# Altium schematic sheet SuperClock_Core_PWR.SchDoc(SuperClock_Core_PWR)
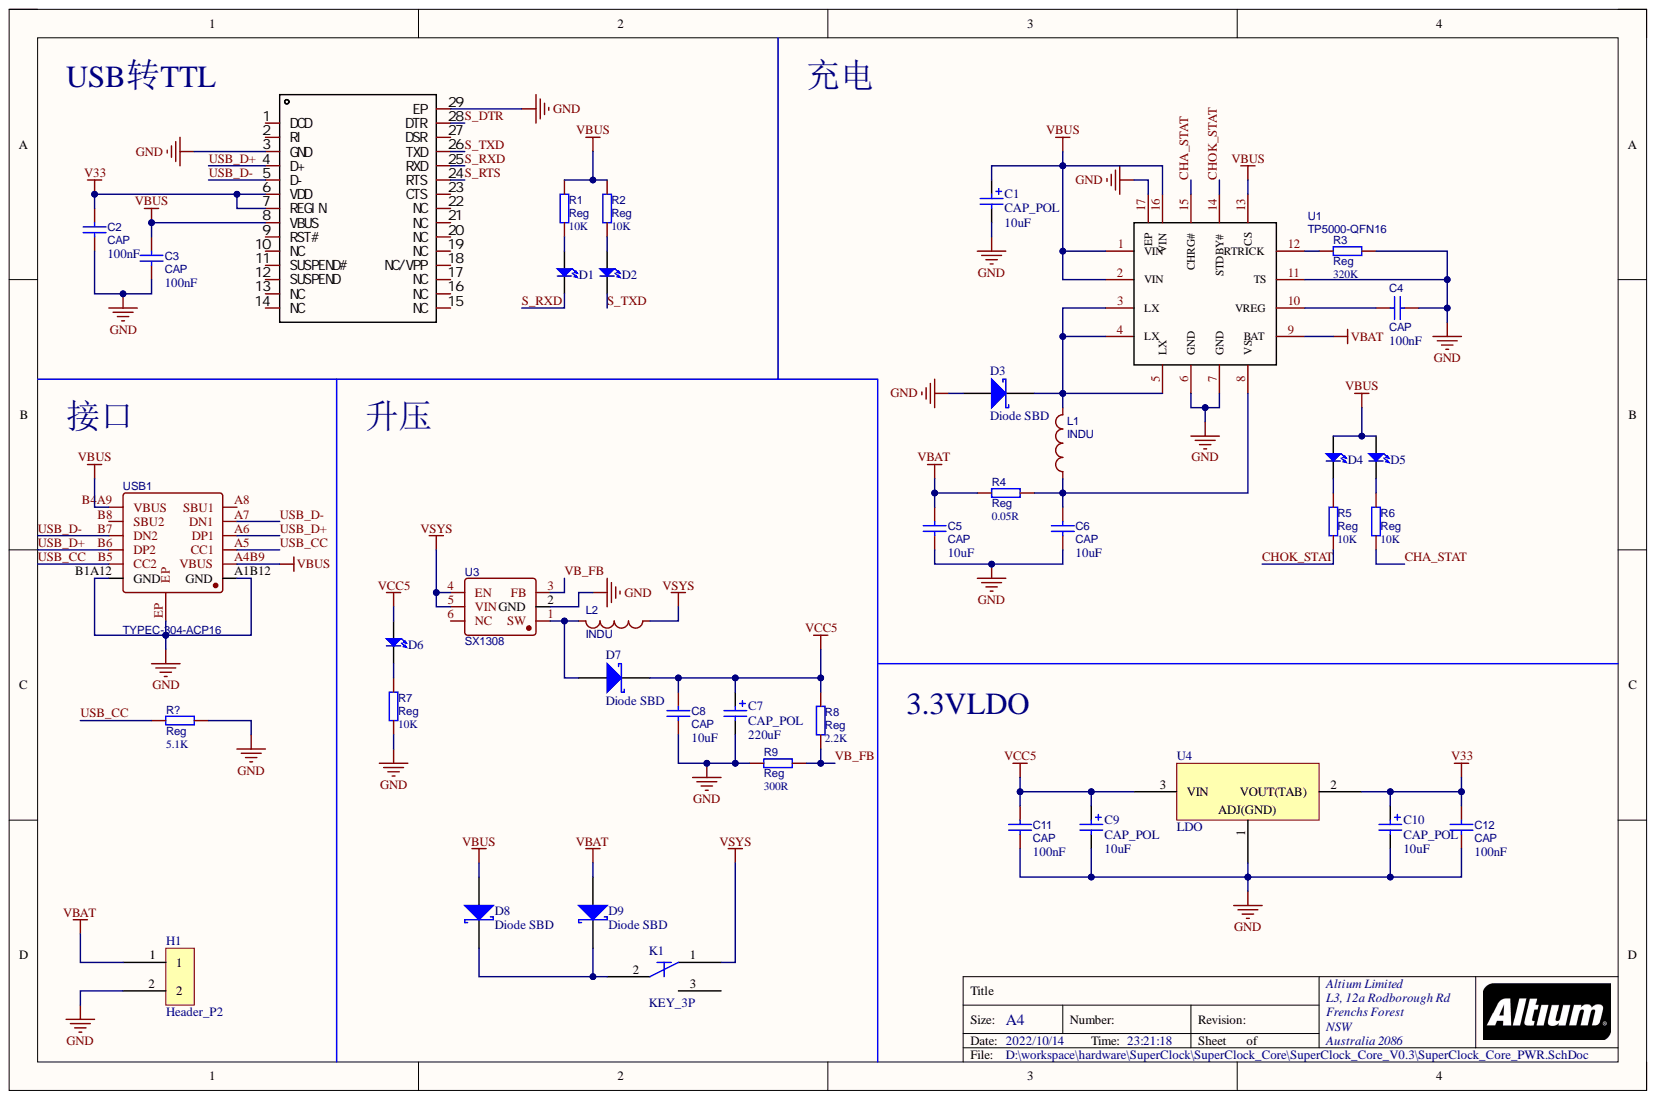
[diagram p0: SuperClock_Core_PWR.SchDoc]

## Parts
C1  CAP_POL  value 10uF  [CP1210]
C2  CAP  value 100nF  [C0603]
C3  CAP  value 100nF  [C0603]
C4  CAP  value 100nF  [C0603]
C5  CAP  value 10uF  [C0603]
C6  CAP  value 10uF  [C0603]
C7  CAP_POL  value 220uF  [CAP_SMD_6.3mm]
C8  CAP  value 10uF  [C0603]
C9  CAP_POL  value 10uF  [CP1210]
C10  CAP_POL  value 10uF  [CP1210]
C11  CAP  value 100nF  [C0603]
C12  CAP  value 100nF  [C0603]
D1  LED  [LED0603]  (value not drawn)
D2  LED  [LED0603]  (value not drawn)
D3  Diode SBD  [SMA_L4.3]
D4  LED  [LED0603]  (value not drawn)
D5  LED  [LED0603]  (value not drawn)
D6  LED  [LED0603]  (value not drawn)
D7  Diode SBD  [SMA_L4.3]
D8  Diode SBD  [SMA_L4.3]
D9  Diode SBD  [SMA_L4.3]
H1  Header_P2  [PH_2Pin]
K1  KEY_3P  [SWITCH_3P_2]
L1  INDU  [SMD_L7.1]
L2  INDU  [SMD_L7.1]
R1  Reg  value 10K  [R0603]
R2  Reg  value 10K  [R0603]
R3  Reg  value 320K  [R0603]
R4  Reg  value 0.05R  [R1206]
R5  Reg  value 10K  [R0603]
R6  Reg  value 10K  [R0603]
R7  Reg  value 10K  [R0603]
R8  Reg  value 2.2K  [R0603]
R9  Reg  value 300R  [R0603]
R?  Reg  value 5.1K  [R0603]
U1  TP5000-QFN16  [QFN-16_L4.0-W4.0-P0.65-BL-EP2.2]
U2  CP2102-GM  [QFN-28]  (value not drawn)
U3  SX1308  [SOT-23-6]
U4  LDO  [SOT-223]
USB1  TYPEC-304-ACP16  [USB-C-SMD_TYPE-C-16P]

## Nets
GND: C1.2 C2.2 C3.2 C4.2 C5.2 C6.2 C7.2 C8.2 C9.2 C10.2 C11.2 C12.2 D3.2 H1.2 R3.2 R7.1 R9.2 R?.1 U1.6(GND) U1.7(GND) U1.11(TS) U1.17(EP) U2.3(GND) U2.29(EP) U3.2(GND) U4.1 USB1.A1B12(GND) USB1.B1A12(GND) USB1.EP
V33: C2.1 C10.1 C12.1 U2.6(VDD) U2.7(REGIN) U4.2
VBAT: C5.1 D9.2 H1.1 R4.1 U1.9(BAT)
VBUS: C1.1 C3.1 D4.2 D5.2 D8.2 R1.2 R2.2 U1.1(VIN) U1.2(VIN) U1.13(CS) U1.16(VIN) U2.8(VBUS) USB1.A4B9(VBUS) USB1.B4A9(VBUS)
VCC5: C7.1 C8.1 C9.1 C11.1 D6.2 D7.1 R8.2 U4.3
VSYS: K1.1 L2.1 U3.4(EN) U3.5(VIN)
CHA_STAT: R6.1 U1.15(CHRG#)
CHOK_STAT: R5.1 U1.14(STDBY#)
S_DTR: U2.28(DTR)
S_RTS: U2.24(RTS)
S_RXD: D1.1 U2.25(RXD)
S_TXD: D2.1 U2.26(TXD)
USB_CC: R?.2 USB1.A5(CC1) USB1.B5(CC2)
USB_D+: U2.4(D+) USB1.A6(DP1) USB1.B6(DP2)
USB_D-: U2.5(D-) USB1.A7(DN1) USB1.B7(DN2)
VB_FB: R8.1 R9.1 U3.3(FB)
NetC4_1: C4.1 U1.10(VREG)
NetC6_1: C6.1 L1.1 R4.2 U1.8(VS)
NetD1_2: D1.2 R1.1
NetD2_2: D2.2 R2.1
NetD3_1: D3.1 L1.2 U1.3(LX) U1.4(LX) U1.5(LX)
NetD4_1: D4.1 R5.2
NetD5_1: D5.1 R6.2
NetD6_1: D6.1 R7.2
NetD7_2: D7.2 L2.2 U3.1(SW)
NetD8_1: D8.1 D9.1 K1.2
NetR3_1: R3.1 U1.12(RTRICK)

Not connected: K1 3; U2 1(DCD) 2(RI) 9(RST#) 10(NC) 11(SUSPEND#) 12(SUSPEND) 13(NC) 14(NC) 15(NC) 16(NC) 17(NC) 18(NC/VPP) 19(NC) 20(NC) 21(NC) 22(NC) 23(CTS) 27(DSR); U3 6(NC); USB1 A8(SBU1) B8(SBU2)

## Library data of the parts
C1 C7 C9 C10 = CAP_POL: 极性电容; Component Kind: Standard; Library Name: Levent_lib.IntLib
C2-C6 C8 C11 C12 = CAP: BOM_Manufacturer: Huaxin S&T; BOM_Manufacturer Part: 0603B103K101CT; Contributor: LCSC; nameAlias: Capacitance; BOM_Supplier: LCSC; BOM_Supplier Part: C302008; Component Kind: Standard; Description: Cap; spicePre: C; spiceSymbolName: 0603B103K101CT; Library Name: Levent_lib.IntLib
D1 D2 D4-D6 = LED0: Component Kind: Standard; Description: LED; LatestRevisionDate: 08-Jul-2005; LatestRevisionNote: Mech Layer 1 removed.; PackageDescription: LED; 2 Leads; PackageReference: LED-0; Published: 8-Jun-2000; Simulation: LED0; Library Name: Levent_lib.IntLib; Publisher: Altium Limited
D3 D7-D9 = Diode SBD: Schottky Rectifier; Note: Terminal 2 is the TAB and is electrically connected to the cathode; Component Kind: Standard; ComponentLink1Description: Manufacturer Link; ComponentLink1URL: http://www.irf.com/; ComponentLink2Description: Datasheet; ComponentLink2URL: http://www.irf.com/product-info/datasheets/data/10tq.pdf; DatasheetVersion: PD-2.057B; LatestRevisionDate: 17-Jul-2002; LatestRevisionNote: Re-released for DXP Platform.; PackageDescription: TO, Thru-Hole, Vertical, Heatsink Mounted; 3 In-Line Leads (1 Absent); Pitch 2.54 mm; PackageReference: TO-220AC; PackageVersion: Feb-1998; Published: 8-Jun-2000; Simulation: 10TQ035; Library Name: Levent_lib.IntLib; Publisher: Altium Limited
H1 = Header_P2: 2Pin排针; Component Kind: Standard; Library Name: Levent_lib.IntLib
K1 = KEY_3P: 3Pin按键; Component Kind: Standard; Library Name: Levent_lib.IntLib
L1 L2 = IND: 电感; BOM_Manufacturer: Sunltech Tech; BOM_Manufacturer Part: SLO0630H150MTT; Contributor: LCSC; nameAlias: Inductance; BOM_JLCPCB Part Class: Extended Part; BOM_Supplier: LCSC; BOM_Supplier Part: C182182; Component Kind: Standard; spicePre: L; spiceSymbolName: SLO0630H150MTT; Library Name: Levent_lib.IntLib
R1-R9 R? = Res: 电阻; BOM_Manufacturer: Viking Tech; BOM_Manufacturer Part: AR03BTCX1002A; Contributor: LCSC; nameAlias: Resistance (Ohms); BOM_Supplier: LCSC; BOM_Supplier Part: C465721; Component Kind: Standard; spicePre: R; spiceSymbolName: AR03BTCX1002A; Library Name: Levent_lib.IntLib
U1 = TP5000-QFN16: BOM_Manufacturer: TOPPOWER; BOM_JLCPCB Part Class: Extended Part; BOM_Manufacturer Part: TP5000-QFN16; BOM_Supplier: LCSC; BOM_Supplier Part: C51699; Component Kind: Standard; spicePre: U; spiceSymbolName: TP5000-QFN16; Library Name: Levent_lib.IntLib
U2 = CP2102-GM: CP2102USB转串口; Manufacturer: SILICON LABS(芯科); Add into BOM: yes; Component Kind: Standard; Convert to PCB: yes; Data Rate: -; Datasheet: https://atta.szlcsc.com/upload/public/pdf/source/20190920/C430012_1BEF61EC258E8DA8CB0C9799CDAE6C98.pdf; Device: CP2102-GM; JLCPCB Part Class: Extended Part; LCSC Part Name: Silicon Labs-MCU; Manufacturer Part: CP2102-GM; Name: CP2102-GM; Number of Drivers/Receivers: -; PCB3D: QFN-28_L5.0-W5.0-P0.50-TL-EP3.2; Protocol: -; Supplier: LCSC; Supplier Footprint: QFN-28; Supplier Part: C430012; Supply Voltage: -; Symbol: CP2102-GM; Type: -; Library Name: Levent_lib.IntLib
U3 = SX1308: 升压PWM; BOM_Manufacturer: SX; sourceId: g4Yg9rJ1j; BOM_JLCPCB Part Class: Extended Part; BOM_Manufacturer Part: SX1308; BOM_Supplier: LCSC; BOM_Supplier Part: C78162; Component Kind: Standard; spicePre: U; spiceSymbolName: SX1308; timeStamp: 1472247539; Library Name: Levent_lib.IntLib
U4 = AMS1117: Component Kind: Standard; Description: LDO; Library Name: Levent_lib.IntLib
USB1 = USB_TYPEC_12P: 全引脚USB TYPEC母座; BOM_Manufacturer: XUNPU(讯普); Contributor: LCSC; BOM_JLCPCB Part Class: Extended Part; BOM_Manufacturer Part: TYPEC-304-ACP16; BOM_Supplier: LCSC; BOM_Supplier Part: C2982555; Component Kind: Standard; spicePre: U; spiceSymbolName: TYPEC-304-ACP16; Library Name: Levent_lib.IntLib
